# Altium schematic sheet SIB VReg.SchDoc
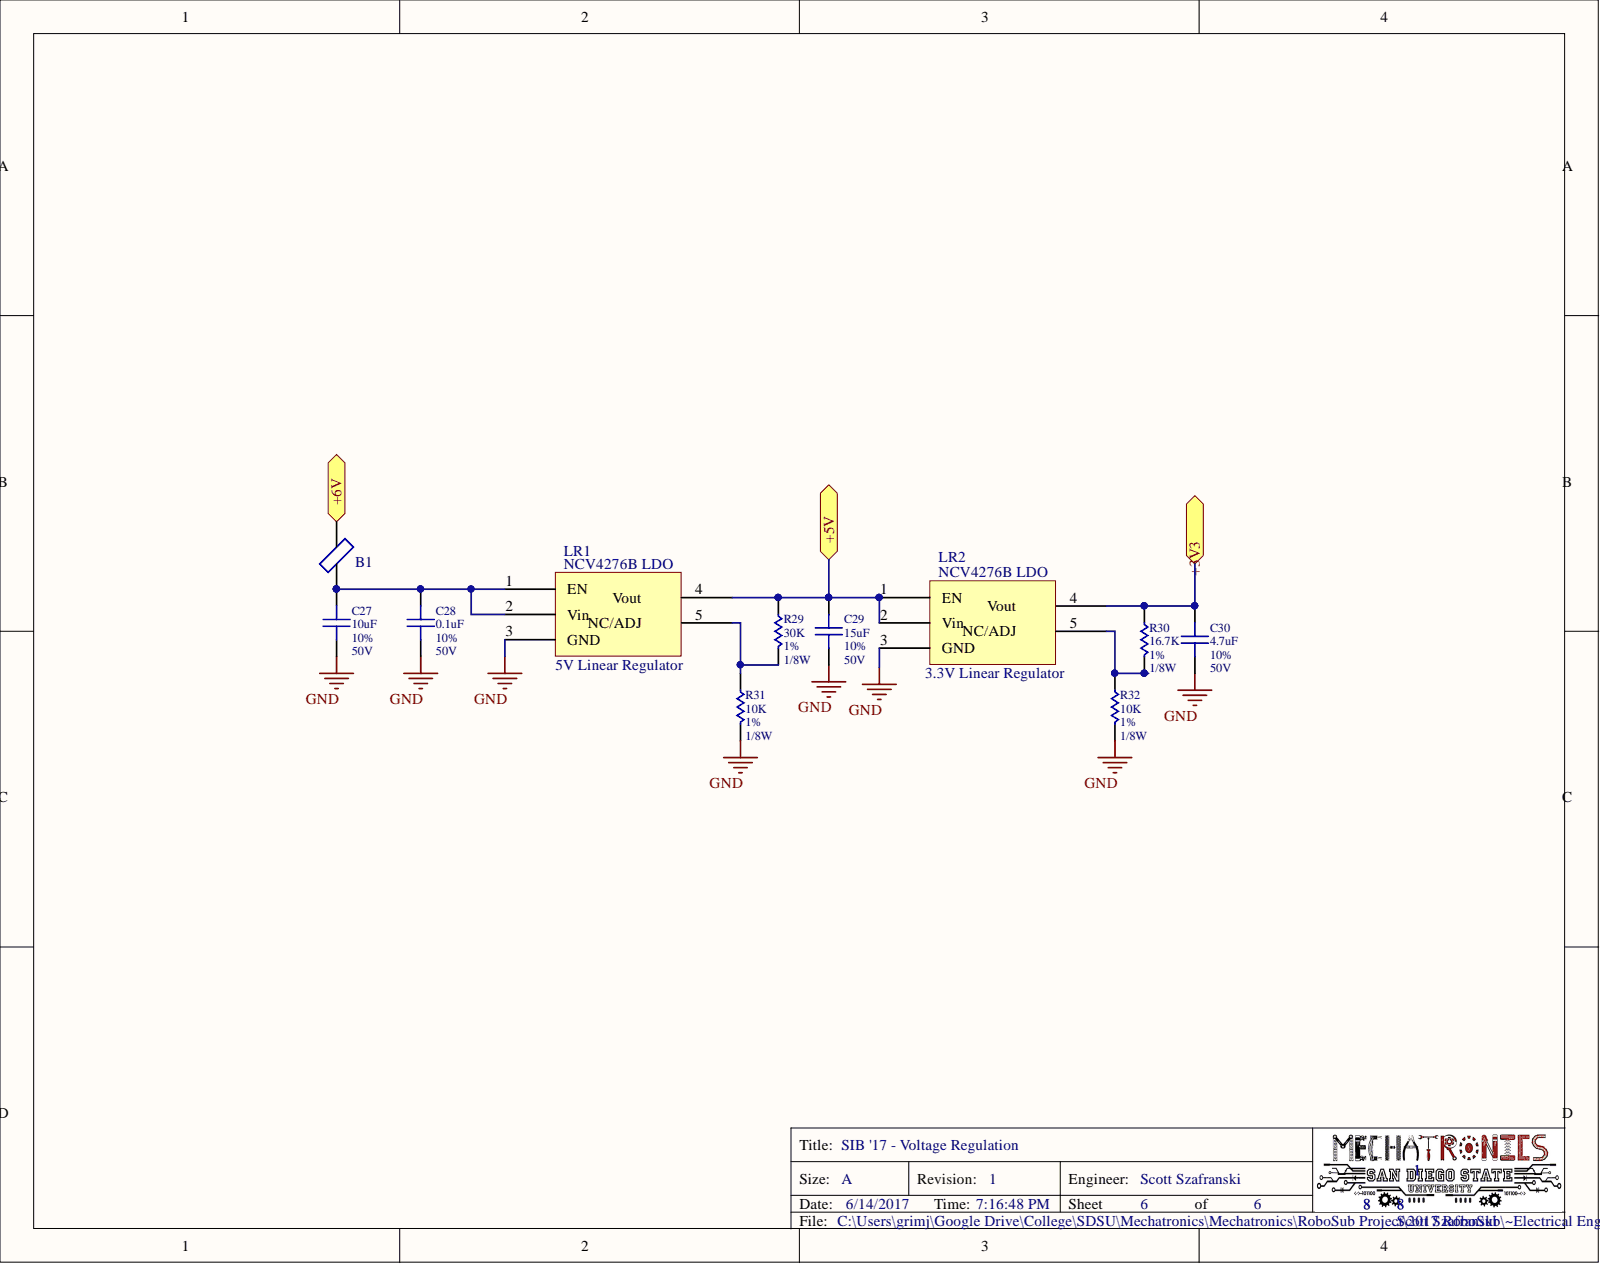
[diagram p0: SIB VReg.SchDoc]

## Parts
B1  Ferrite Bead  [Component 0805]  (value not drawn)
C27  10uF  Tolerance: 10%  Voltage: 50V  [Component 0805]
C28  0.1uF  Tolerance: 10%  Voltage: 50V  [Component 0805]
C29  15uF  Tolerance: 10%  Voltage: 50V  [Component 0805]
C30  4.7uF  Tolerance: 10%  Voltage: 50V  [Component 0805]
LR1  5V Linear Regulator  [DPAK-5]
LR2  3.3V Linear Regulator  [DPAK-5]
R29  Power: 1/8W  Resistance: 30K  Tolerance: 1%  [Component 0805]  (hidden comment: Resistor)
R30  Power: 1/8W  Resistance: 16.7K  Tolerance: 1%  [Component 0805]  (hidden comment: Resistor)
R31  Power: 1/8W  Resistance: 10K  Tolerance: 1%  [Component 0805]  (hidden comment: Resistor)
R32  Power: 1/8W  Resistance: 10K  Tolerance: 1%  [Component 0805]  (hidden comment: Resistor)

## Ports
+3V3, +5V, +6V

## Nets
GND: C27.2 C28.2 C29.2 C30.2 LR1.3(GND) LR2.3(GND) R31.2 R32.2
+3V3: C30.1 LR2.4 R30.1 ; port +3V3
+5V: C29.1 LR1.4 LR2.1(EN) LR2.2(Vin) R29.1 ; port +5V
+6V: B1.2 ; port +6V
NetB1_1: B1.1 C27.1 C28.1 LR1.1(EN) LR1.2(Vin)
NetLR1_5: LR1.5 R29.2 R31.1
NetLR2_5: LR2.5 R30.2 R32.1

## Library data of the parts
B1 = Ferrite Bead: Ferrite Bead: 200 Ohm @ 25 Mhz; Component Kind: Standard; Library Name: MechatronicsSCH.SchLib
C27-C30 = Capacitor, Ceramic: Component Kind: Standard; Library Name: MechatronicsSCH.SchLib
  C27: Capacitance: 10uF
  C28: Capacitance: 0.1uF
  C29: Capacitance: 15uF
  C30: Capacitance: 4.7uF
LR1 LR2 = NCV4276B LDO DPAK-5: Component Kind: Standard; Library Name: PMUD.SchLib
R29-R32 = Resistor: Thick Film Resistors - SMD 1/16watt 1%; Component Kind: Standard; Library Name: MechatronicsSCH.SchLib
